# Altium schematic sheet interface.SchDoc(interface)
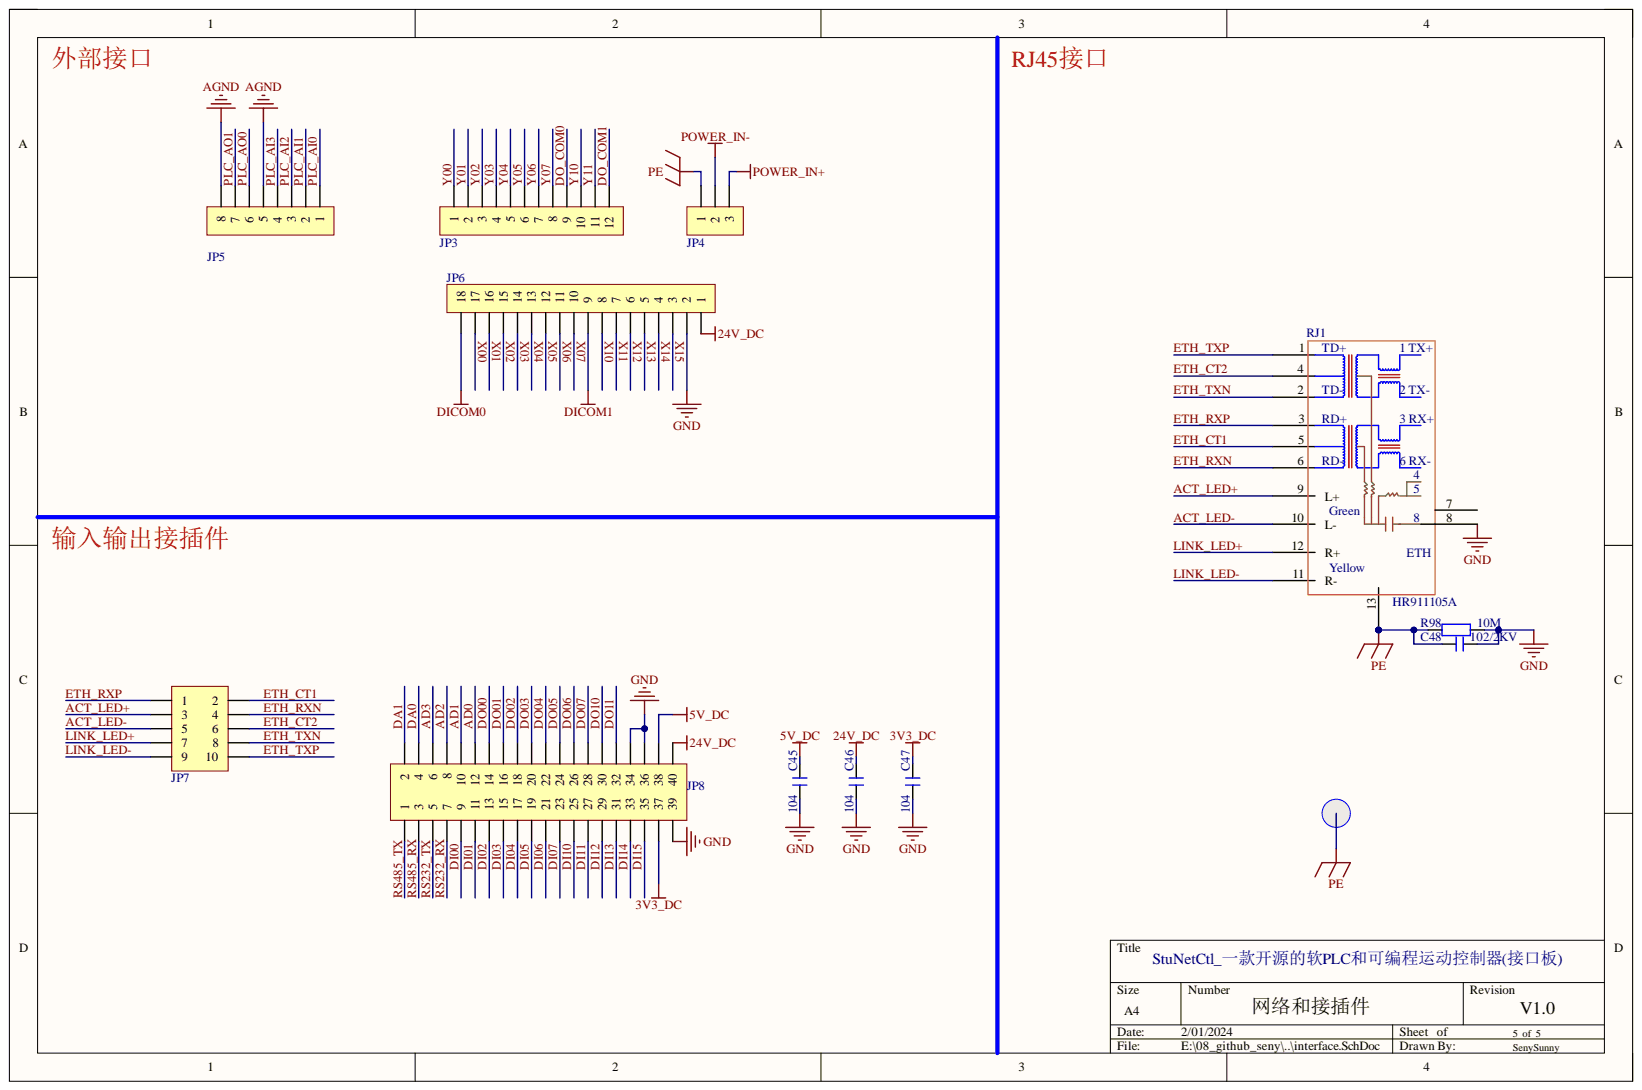
[diagram p0: interface.SchDoc]

## Parts
C45  104  [C 0603]
C46  104  [C 0603]
C47  104  [C 0603]
C48  102/2KV  [C 1206]
JP3  HDR-1X12  [2EDGKG-5.08_12P]  (value not drawn)
JP4  HDR-1X3  [2EDGKG-5.08_3P]  (value not drawn)
JP5  HDR-1X8  [KF2EDGK3.81-8P_H]  (value not drawn)
JP6  HDR-1X18  [2EDGKG-5.08_18P]  (value not drawn)
JP7  Header 5X2  [HDR2.54 2x5-SMD]  (value not drawn)
JP8  Header 2X11  [HDR-20X2]  (value not drawn)
R98  10M  [R 1206]
RJ1  HR911105A  [RJ-45A12]
T1  测试点  [Test_1P_3]  (value not drawn)

## Nets
3V3_DC: C47.1 JP8.37
5V_DC: C45.1 JP8.38
24V_DC: C46.1 JP6.1 JP8.40
AGND: JP5.5 JP5.8
DICOM0: JP6.18
DICOM1: JP6.9
GND: C45.2 C46.2 C47.2 C48.1 JP6.2 JP8.34 JP8.36 JP8.39 R98.1 RJ1.8
PE: C48.2 JP4.1 R98.2 RJ1.13 T1.0
POWER_IN+: JP4.3
POWER_IN-: JP4.2
ACT_LED+: JP7.3 RJ1.9(L+)
ACT_LED-: JP7.5 RJ1.10(L-)
AD0: JP8.12
AD1: JP8.10
AD2: JP8.8
AD3: JP8.6
DA0: JP8.4
DA1: JP8.2
DI00: JP8.9
DI01: JP8.11
DI02: JP8.13
DI03: JP8.15
DI04: JP8.17
DI05: JP8.19
DI06: JP8.21
DI07: JP8.23
DI10: JP8.25
DI11: JP8.27
DI12: JP8.29
DI13: JP8.31
DI14: JP8.33
DI15: JP8.35
DO00: JP8.14
DO01: JP8.16
DO02: JP8.18
DO03: JP8.20
DO04: JP8.22
DO05: JP8.24
DO06: JP8.26
DO07: JP8.28
DO10: JP8.30
DO11: JP8.32
DO_COM0: JP3.9
DO_COM1: JP3.12
ETH_CT1: JP7.2 RJ1.5
ETH_CT2: JP7.6 RJ1.4
ETH_RXN: JP7.4 RJ1.6
ETH_RXP: JP7.1 RJ1.3
ETH_TXN: JP7.8 RJ1.2
ETH_TXP: JP7.10 RJ1.1(TD+)
LINK_LED+: JP7.7 RJ1.12(R+)
LINK_LED-: JP7.9 RJ1.11(R-)
PLC_AI0: JP5.1
PLC_AI1: JP5.2
PLC_AI2: JP5.3
PLC_AI3: JP5.4
PLC_AO0: JP5.6
PLC_AO1: JP5.7
RS232_RX: JP8.7
RS232_TX: JP8.5
RS485_RX: JP8.3
RS485_TX: JP8.1
X00: JP6.17
X01: JP6.16
X02: JP6.15
X03: JP6.14
X04: JP6.13
X05: JP6.12
X06: JP6.11
X07: JP6.10
X10: JP6.8
X11: JP6.7
X12: JP6.6
X13: JP6.5
X14: JP6.4
X15: JP6.3
Y00: JP3.1
Y01: JP3.2
Y02: JP3.3
Y03: JP3.4
Y04: JP3.5
Y05: JP3.6
Y06: JP3.7
Y07: JP3.8
Y10: JP3.10
Y11: JP3.11

Not connected: RJ1 7

## Library data of the parts
C45-C48 = C: 无极性贴片电容; 版权归属: 源创客; BOM名称: 无极性贴片电容; Component Kind: Standard; 学习资源: www.ooocke.com; Library Name: zblib_v1.IntLib
JP3 = Header 12: 12P接插件; 版权归属: 源创客; BOM名称: 12P接插件; Component Kind: Standard; 学习资源: www.ooocke.com; Library Name: zblib_v1.IntLib
JP4 = Header 3: 3P接插件; 版权归属: 源创客; BOM名称: 3P接插件; Component Kind: Standard; 学习资源: www.ooocke.com; Library Name: zblib_v1.IntLib
JP5 = Header 8: 8P接插件; 版权归属: 源创客; BOM名称: 8P接插件; Component Kind: Standard; 学习资源: www.ooocke.com; Library Name: zblib_v1.IntLib
JP6 = Header 18: 18P接插件; 版权归属: 源创客; BOM名称: 18P接插件; Component Kind: Standard; 学习资源: www.ooocke.com; Library Name: zblib_v1.IntLib
JP7 = Header 5X2: 5*2P接插件; 版权归属: 源创客; BOM名称: 5*2P接插件; Component Kind: Standard; 学习资源: www.ooocke.com; Library Name: zblib_v1.IntLib
JP8 = Header 20X2: 20*2P接插件; 版权归属: 源创客; BOM名称: 20*2P接插件; Component Kind: Standard; 学习资源: www.ooocke.com; Library Name: zblib_v1.IntLib
R98 = R: 贴片电阻; 版权归属: 源创客; BOM名称: 贴片电阻; Component Kind: Standard; 学习资源: www.ooocke.com; Library Name: zblib_v1.IntLib
RJ1 = HR911105A: 网络变压器带灯以太网接口; 版权归属: 源创客; BOM名称: 带灯以太网接口; Component Kind: Standard; 学习资源: www.ooocke.com; Library Name: zblib_v1.IntLib
T1 = TEST_PT: Component Kind: Standard; Library Name: zblib_v1.IntLib
